# Altium schematic sheet SmartMeter.SchDoc(SmartMeter)
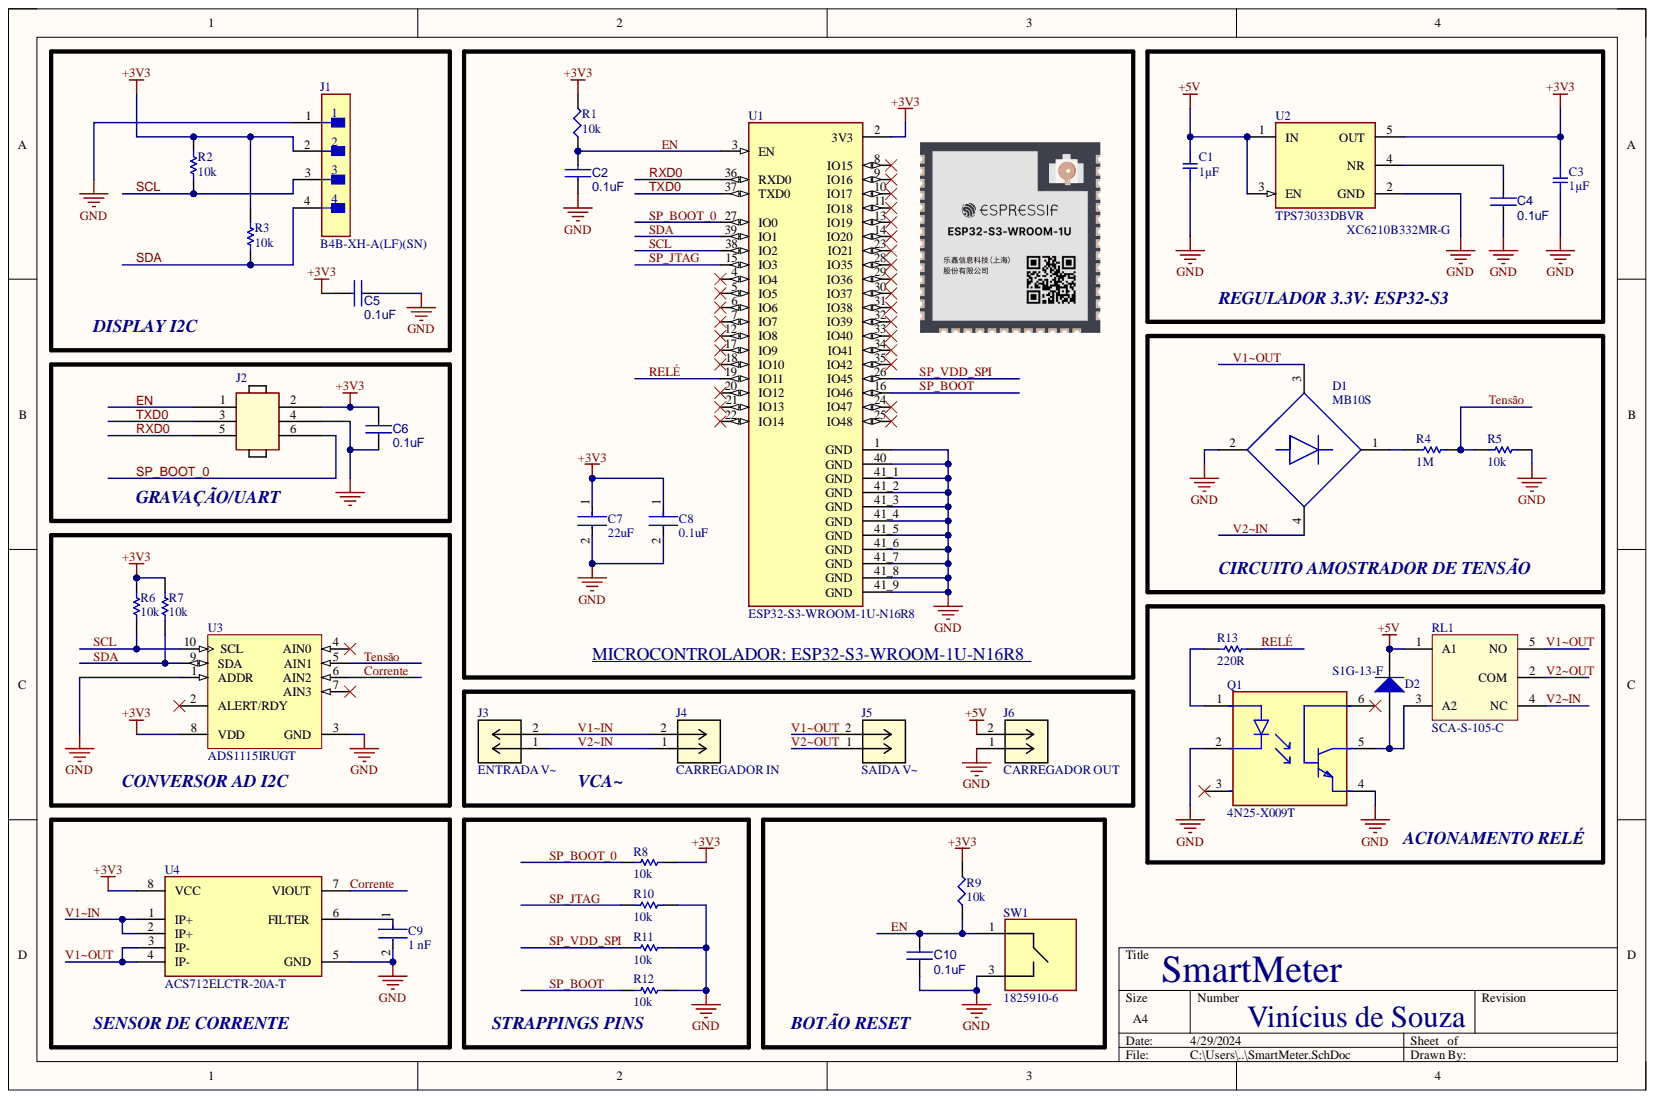
[diagram p0: SmartMeter.SchDoc]

## Parts
C1  1µF  [SMD-0805C]
C2  0.1uF  [C_0603]
C3  1µF  [SMD-0805C]
C4  0.1uF  [C_0603]
C5  0.1uF  [C_0603]
C6  0.1uF  [C_0603]
C7  22uF  [FP-C0603C104K5RAC3121-IPC_A]
C8  0.1uF  [FP-C0603C104K5RAC3121-IPC_A]
C9  1 nF  [FP-C0603C-CF-IPC_B]
C10  0.1uF  [C_0603]
D1  MB10S  [FP-751EP-IPC_A]
D2  S1G-13-F  [DIOD-SMA-2_V]  (no BOM)
J1  B4B-XH-A(LF)(SN)  [FP-B4B-XH-A_LF_SN-MFG]
J2  GRPB032VWVN-RC  [HDR6_M_P127]  (value not drawn)
J3  ENTRADA V~  [CONN_1715721_PXC]  (no BOM)
J4  CARREGADOR IN  [CONN_1715721_PXC]  (no BOM)
J5  SAÍDA V~  [CONN_1715721_PXC]  (no BOM)
J6  CARREGADOR OUT  [CONN_1715721_PXC]  (no BOM)
Q1  4N25-X009T  [FP-4N25-X009T-MFG]
R1  10k  [SMD-0603-RES]
R2  10k  [RESC1609X50X30LL8T20]
R3  10k  [RESC1609X50X30LL8T20]
R4  1M  [RESC1608X55X25NL10T15]
R5  10k  [RESC1609X50X30LL8T20]
R6  10k  [RESC1609X50X30LL8T20]
R7  10k  [RESC1609X50X30LL8T20]
R8  10k  [RESC1609X50X30LL8T20]
R9  10k  [SMD-0603-RES]
R10  10k  [RESC1609X50X30LL8T20]
R11  10k  [RESC1609X50X30LL8T20]
R12  10k  [RESC1609X50X30LL8T20]
R13  220R  [RESC1609X50X30LL8T20]
RL1  SCA-S-105-C  [RELAY_5V_MINI]
SW1  1825910-6  [18259106]
U1  ESP32-S3-WROOM-1U-N16R8  [XCVR_ESP32-S3-WROOM-1U-N16R8]
U2  TPS73033DBVR  [FP-DBV0005A-MFG]
U3  ADS1115IRUGT  [TI-RUG10_V]
U4  ACS712ELCTR-20A-T  [FP-LC-8-IPC_B]

## Nets
+3V3: C3.1 C5.2 C6.2 C7.1 C8.1 J1.2 J2.2 R1.2 R2.2 R3.2 R6.2 R7.2 R8.2 R9.2 U1.2(3V3) U2.5(OUT) U3.8(VDD) U4.8(VCC)
+5V: C1.1 D2.2 J6.2 RL1.1(A1) U2.1(IN) U2.3(EN)
GND: C1.2 C2.1 C3.2 C4.1 C5.1 C6.1 C7.2 C8.2 C9.2 C10.1 D1.2 J1.1 J2.4 J6.1 Q1.2 Q1.4 R5.2 R10.2 R11.2 R12.2 SW1.3 U1.1(GND) U1.40(GND) U1.41_1(GND) U1.41_2(GND) U1.41_3(GND) U1.41_4(GND) U1.41_5(GND) U1.41_6(GND) U1.41_7(GND) U1.41_8(GND) U1.41_9(GND) U2.2(GND) U3.1(ADDR) U3.3(GND) U4.5(GND)
Corrente: U3.6(AIN2) U4.7(VIOUT)
EN: C2.2 C10.2 J2.1 R1.1 R9.1 SW1.1 U1.3(EN)
RELÉ: R13.2 U1.19(IO11)
RXD0: J2.5 U1.36(RXD0)
SCL: J1.3 R2.1 R6.1 U1.38(IO2) U3.10(SCL)
SDA: J1.4 R3.1 R7.1 U1.39(IO1) U3.9(SDA)
SP_BOOT: R12.1 U1.16(IO46)
SP_BOOT_0: J2.6 R8.1 U1.27(IO0)
SP_JTAG: R10.1 U1.15(IO3)
SP_VDD_SPI: R11.1 U1.26(IO45)
Tensão: R4.2 R5.1 U3.5(AIN1)
TXD0: J2.3 U1.37(TXD0)
V1~IN: J3.2 J4.2 U4.1(IP+) U4.2(IP+)
V1~OUT: D1.3 J5.2 RL1.5(NO) U4.3(IP-) U4.4(IP-)
V2~IN: D1.4 J3.1 J4.1 RL1.4(NC)
V2~OUT: J5.1 RL1.2(COM)
NetC4_2: C4.2 U2.4(NR)
NetC9_1: C9.1 U4.6(FILTER)
NetD1_1: D1.1 R4.1
NetD2_1: D2.1 Q1.5 RL1.3(A2)
NetQ1_1: Q1.1 R13.1

Not connected: Q1 3(NC) 6; U1 4(IO4) 5(IO5) 6(IO6) 7(IO7) 8(IO15) 9(IO16) 10(IO17) 11(IO18) 12(IO8) 13(IO19) 14(IO20) 17(IO9) 18(IO10) 20(IO12) 21(IO13) 22(IO14) 23(IO21) 24(IO47) 25(IO48) 28(IO35) 29(IO36) 30(IO37) 31(IO38) 32(IO39) 33(IO40) 34(IO41) 35(IO42); U3 2(ALERT/RDY) 4(AIN0) 7(AIN3)

## Library data of the parts
C1 C3 = GCJ21BR71E105KA12L: CAP CER 1UF 25V X7R 0805; Manufacturer: Murata Electronics North America; @ qty: 0; Minimum Quantity: 1; Tolerance: ±10%; Unit Price (USD): 0.36; Applications: Automotive, Boardflex Sensitive; Component Kind: Standard; Datasheets: http://www.murata.com/~/media/webrenewal/support/library/catalog/products/capacitor/mlcc/c03e.ashx; Digi-Key Part Number: 490-5802-1-ND; Factory Stock: 0; Features: Soft Termination; Height - Seated (Max): -; Image: //media.digikey.com/Renders/Murata%20Renders/0805%20(2012%20Metric)%20.85mm.jpg; Lead Spacing: -; Lead Style: -; Library Name: capacitor_murata_0805.IntLib; Mounting Type: Surface Mount, MLCC; Operating Temperature: -55°C ~ 125°C; Package / Case: 0805 (2012 Metric); Packaging: Cut Tape (CT); Part Number: GCJ21BR71E105KA12L; Part Status: Active; Quantity Available: 15950; Ratings: AEC-Q200; Series: GCJ; Size / Dimension: 0.079" L x 0.049" W (2.00mm x 1.25mm); Temperature Coefficient: X7R; Thickness (Max): 0.057" (1.45mm); Value: 1µF; Voltage: 25V
C2 C4-C6 C10 = CAP NP: CAP CER 0.1UF 50V X7R 0603; Manufacturer: Murata Electronics; Manufacturer_Part_Number: C0603C104K5RAC3121; ManufacturerPN: GCJ188R71H104KA12D; PartNumber: FITC0000362; SchematicPart: discrete\CAPACITOR NON-POL; Capacitance: 100nF; LargeQuantityPrice: 0,02167; Obsolete: False; PCBFootPrint: smc0603; PCBFootPrint3D: 0603C; SmallQuantintyPrice: 0,24; Tolerance: ± 10 %; Voltage: 50.00 V; Availability: Active; Component Kind: Standard; Datasheet: https://www.murata.com/~/media/webrenewal/support/library/catalog/products/capacitor/mlcc/c03e.ashx?la=en-us; Distributor: Digikey; DistributorPN: 490-5798-6-ND; Package: 0603; PartType: Ceramic Capacitors; Temperature: -55° C ~ 125° C; Value: 0.1uF; Library Name: SMARTBIN.SCHLIB
  C2 C4 C5 C10: Case/Package: 0603
C7 C8 = CMP-03020-034644-1: CAP CER 0.1UF 50V X7R 0603; Case/Package: 0603; Component Kind: Standard; Library Name: Altium Content Vault; RoHS Compliant: Yes
C9 = CMP-03020-005970-1: C0603 1,000 pF X8R 30ppm/°C 1.00% 10 V  C0603C102F8HACAUTO; Manufacturer: KEMET; Automotive Grade: Grade 1; Material: Ceramic; Tolerance: 0.01; Voltage Rating: 10 V; Automotive: Yes; Capacitance: 1,000 pF; Category: Cap; Component Kind: Standard; ComponentLink2Description: Datasheet; ComponentLink2URL: https://api.kemet.com/component-edge/download/datasheet/C0603C100J5GACAUTO.pdf; Device Class L1: Passive Components; Device Class L2: Capacitors; Device Class L3: Ceramic Capacitors; Height: 0.87mm; Lead Free: Yes; Library Name: Altium Content Vault; Manufacturer Part Number: C0603C102F8HACAUTO; Octopart: https://octopart.com/c0603c102f8hacauto-kemet-88083731; Package: C0603C-CF; RoHS: TRUE; Temperature: -55°C to +125°C; Temperature Characteristic: X8R; Temperature Coefficient: 30ppm/°C; Temperature Range High: +125°C; Temperature Range Low: -55°C; Value: 1,000 pF
D1 = CMP-07163-000045-1: BRIDGE RECT 1P 1KV 500MA 4SOIC; Capacitance: 470pF; Element Configuration: Single; Forward Current: 800mA; Forward Voltage: 1V; Lead/Base Style: Formed Leads; Max Forward Surge Current (Ifsm): 35A; Max Power Dissipation: 1.4W; Number of Elements: 1; Peak Reverse Current: 5uA; Tolerance: 10%; Voltage Rating: 500V; Average Rectified Current: 500mA; Case Code (Imperial): 1206; Case Code (Metric): 3216; Case/Package: SOIC; Component Kind: Standard; Contact Plating: Tin; Height: 2.7mm; Height - Seated (Max): 0.354inch; Lead Free: Lead Free; Lead Pitch: 0.197inch; Length: 4.95mm; Library Name: MB10S.IntLib; Max Operating Temperature: 150°C; Max Repetitive Reverse Voltage (Vrrm): 1kV; Max Surge Current: 35A; Min Operating Temperature: -55°C; Mount: Surface Mount; Number of Pins: 4; Package Quantity: 500; Packaging: Tape and Reel; Peak Non-Repetitive Surge Current: 35A; Radiation Hardening: No; REACH SVHC: No SVHC; Reverse Voltage (DC): 1kV; Thickness: 0.039inch; Weight: 0.228g; Width: 4.2mm
D2 = CMP-2000-07012-1: Glass Passivated Rectifier, 1 A, 400 V, -65 to 150 degC, 2-Pin SMD, RoHS, Tape and Reel; Manufacturer: Diodes Inc; Average Rectified Current-Max: 1A; Forward Voltage: 1.1V; Peak Current-Max: 30A; Reverse Current-Max: 5µA; Reverse Voltage-Max [Vrrm]: 400V; Datasheet URL: http://www.diodes.com/_files/datasheets/ds16003.pdf; Datasheet Version: Rev. 24-2, 12/2014; Library Name: Altium Content Vault; Manufacturer URL: http://www.diodes.com/; Mounting Technology: SurfaceMount; Package Description: 2-Pin Surface Mounted Assembly, Body 4.3 x 2.605 mm, Height 2.4 mm; Package Reference: SMA-2
J1 = CMP-17439-000250-1: CONN HEADER VERT 4POS 2.5MM; Contact Resistance: 20mR; Current Rating: 3A; Gender: Male; Insulation Resistance: 1GR; Mated Stacking Heights: 9.8mm; Max Voltage Rating (AC): 250V; Number of Contacts: 4; Wire/Cable Gauge: 22AWG; Color: Natural; Component Kind: Standard; Connector Type: Header; Contact Finish - Mating: TIN; Contact Material: Brass; Contact Plating: Tin; Contact Shape: Square; Contact Type: Male Pin; Depth: 5.75mm; Fastening Type: Detent; Flammability Rating: UL94 V-0; Housing Color: White; Housing Material: Polyamide; Insulation Color: Natural; Insulation Material: Nylon; Lead Free: Lead Free; Lead Pitch: 2.5mm; Length: 12.4mm; Library Name: Altium Content Vault; Material: Polyamide; Max Current Rating: 3A; Max Operating Temperature: 85°C; Max Voltage Rating (DC): 250V; Min Operating Temperature: -25°C; Mount: Through Hole; Number of Positions: 4; Number of Rows: 1; Orientation: Straight; Package Quantity: 500; Packaging: Bulk; Pitch: 2.5mm; REACH SVHC: No SVHC; Series: XH; Termination: Solder; Voltage Rating: 250V; Voltage Rating (AC): 250V
J2 = HEADER 3X2 1.27mm: CONN HEADER VERT 6POS 1.27MM; Manufacturer: Sullins Connector Solutions; PartNumber: FITJ0000168; SchematicPart: CONNECTOR\HEADER 3X2; LargeQuantityPrice: 0,2158; NumberOfPositions: 6; Obsolete: False; SmallQuantintyPrice: 0,48; Voltage: 0.00 V; Availability: Active; Component Kind: Standard; Datasheet: https://media.digikey.com/pdf/Data%20Sheets/Sullins%20PDFs/GRPB_%20_2VWVN-RC%2010954-C.pdf; Distributor: Digikey; DistributorPN: S9015E-03-ND; ManufacturerPN: GRPB032VWVN-RC; PartType: Header; Temperature: -40° C ~ 105° C; Value: GRPB032VWVN-RC; Library Name: Pollux.IntLib
J3-J6 = 1715721: TERM BLK 2P SIDE ENT 5.08MM PCB; Manufacturer: Phoenix Contact; Approvals: UL,CSA,cULus,EAC; Connector Type: Screw,Terminal Block,PCB; Current: 15A; Current Rating: 17.5A; Max Current Rating: 22A; Max Supply Voltage: 250V; Number of Circuits: 2; Number of Levels: 1; Stripping Length: 7mm; Test Voltage: 4kV; Voltage: 300V; Voltage Rating: 400V; Wire Gauge (Max): 26AWG; Wire Gauge (Min): 14AWG; Category: Terminal Blocks; Color: Green; ComponentLink1Description: https://datasheet.ciiva.com/16046/1715721-16046034.pdf?src-supplier=Onlinecomponents.com; ComponentLink1URL: https://datasheet.ciiva.com/16046/1715721-16046034.pdf?src-supplier=Onlinecomponents.com; ComponentLink2Description: https://datasheet.ciiva.com/15169/getdatasheetpartid-212174-15169929.pdf?src-supplier=Verical; ComponentLink2URL: https://datasheet.ciiva.com/15169/getdatasheetpartid-212174-15169929.pdf?src-supplier=Verical; ComponentLink3Description: https://datasheet.ciiva.com/832/1715721-832095.pdf?src-supplier=Digi-Key; ComponentLink3URL: https://datasheet.ciiva.com/832/1715721-832095.pdf?src-supplier=Digi-Key; ComponentLink4Description: https://datasheet.ciiva.com/1120/1697161-1120393.pdf?src-supplier=Farnell; ComponentLink4URL: https://datasheet.ciiva.com/1120/1697161-1120393.pdf?src-supplier=Farnell; Contact Material: Tin; Depth: 9.8mm; Flammability Rating: UL94 V-0; Height: 17.3mm; Housing Color: Green; Housing Material: Polyamide; Insulation Material: Nylon; Lead Free: Lead Free; Length: 10.16mm; ManufacturerPN: 1715721; Max Operating Temperature: 100°C; Min Operating Temperature: -20°C; Mount: Through Hole; Number of Contacts: 2; Number of Pins: 2; Number of Poles: 2; Number of Positions: 2; Number of Positions Per Level: 2; Number of Rows: 1; Orientation: Straight; Package Quantity: 50; Packaging: Bulk; Pitch: 5.08mm; Pricing 1: 5=1,16200, 75=1,06000, 250=0,96800, 500=0,89200, 1000=0,82200 (GBP); Radiation Hardening: No; Reach SVHC Compliant: Yes; RoHS: Yes; RoHS Compliant: Yes; Series: MKDS; Stock 1: 2745; Supplier 1: Rs; Supplier Part Number 1: 1930564; Termination: Screw; Voltage Rating (AC): 250V; Weight: 0.103705oz; Width: 13.8mm; Wire/Cable Gauge: 14AWG; Library Name: POC_RELES.SchLib
Q1 = CMP-00030-12292229-1: 4N25-X009T Optocoupler DC-IN 1-CH Transistor With Base DC-OUT 6-Pin PDIP SMD T/R; Collector Emitter Saturation Voltage: 500mV; Collector Emitter Voltage (VCEO): 70V; Current Transfer Ratio: 50%; Forward Current: 60mA; Forward Voltage: 1.36V; Max Collector Current: 100mA; Max Power Dissipation: 150mW; Number of Channels: 1; Output Current per Channel: 50mA; Reverse Breakdown Voltage: 6V; Case/Package: SMD/SMT; Component Kind: Standard; Contact Plating: Tin; Lead Free: Lead Free; Library Name: 4N25.IntLib; Max Input Current: 60mA; Max Operating Temperature: 100°C; Max Output Voltage: 70V; Min Operating Temperature: -55°C; Mount: Surface Mount; NexarPartId: 12292229; Number of Elements: 1; Number of Pins: 6; Packaging: Tape & Reel (TR); Power Dissipation: 150mW; Radiation Hardening: No; RoHS: Compliant
R1 R9 = ERJ-3EKF1002V: RES SMD 10K OHM 1% 1/10W 0603; Manufacturer: Panasonic Electronic Components; @ qty: 1500; Factory Stock: 0; Minimum Quantity: 1; Power (Watts): 0.1W, 1/10W; Temperature Coefficient: ±100ppm/°C; Unit Price (USD): 0.00379; Component Kind: Standard; Composition: Thick Film; Datasheets: http://industrial.panasonic.com/www-cgi/jvcr13pz.cgi?E+PZ+3+AOA0002+ERJ3EKF1002V+7+WW; Digi-Key Part Number: P10.0KHCT-ND; Failure Rate: -; Features: Automotive AEC-Q200; Height - Seated (Max): 0.022" (0.55mm); Image: //media.digikey.com/Photos/Panasonic%20Photos/MFG_ERJ-P6W%20Series.jpg; Library Name: Panasonic_ERJ_0603.IntLib; Number of Terminations: 2; Operating Temperature: -55°C ~ 155°C; Package / Case: 0603 (1608 Metric); Packaging: Cut Tape (CT); Part Number: ERJ-3EKF1002V; Part Status: Active; Quantity Available: 6703141; Series: ERJ; Size / Dimension: 0.063" L x 0.031" W (1.60mm x 0.80mm); Supplier Device Package: 0603; Tolerance: ±1%; Value: 10k
R2 R3 R5-R8 R10-R13 = CMP-1666-00005-4: Chip Resistor, 10 KOhm, +/- 1%, 100 mW, -55 to 155 degC, 0603 (1608 Metric), RoHS, Tape and Reel; Manufacturer: Vishay Semiconductor; Voltage Rating: 75 V; Component Kind: Standard; ComponentLink1Description: Manufacturer URL; ComponentLink1URL: http://www.vishay.com/; ComponentLink2Description: Datasheet; ComponentLink2URL: https://datasheet.ciiva.com/11809/0900766b80d98afc-11809037.pdf; DatasheetVersion: 06/2012; Height: 0.45 mm; Length: 1.55 mm; Library Name: Altium Content Vault; Max Operating Temperature: 155 degC; Min Operating Temperature: -55 degC; Mounting Technology: Surface Mount; Package Quantity: 5000; PackageDescription: 2-Pin Surface Mount Device, Body 1.575 x 0.85 mm; PackageReference: 0603; Packaging: Tape and Reel; REACH SVHC: Unknown; RoHSCompliant: true
R4 = CMP-1659-00056-1: Chip Resistor, 1 MOhm, +/- 1%, 100 mW, -55 to 155 degC, 0603 (1608 Metric), RoHS, Tape and Reel; Capacitance: 1 mF; Case\Package: Radial; Tolerance: 20%; Voltage Rating: 25 V; Component Kind: Standard; ComponentLink1Description: Manufacturer URL; ComponentLink1URL: http://www.yageo.com/; ComponentLink2Description: Datasheet; ComponentLink2URL: http://www.yageo.com/documents/recent/PYu-RC_Group_51_RoHS_L_04.pdf; DatasheetVersion: Rev. 4, 02/2016; Diameter: 10 mm; Height: 20 mm; Lead Pitch: 5 mm; Length: 20 mm; Library Name: 06031M.IntLib; Max Operating Temperature: 85 degC; Min Operating Temperature: -40 degC; Mount: Through Hole; Package Quantity: 200; PackageDescription: 2-Pin Surface Mount Device, Body 1.6 x 0.8 mm; PackageReference: 0603; Packaging: Bulk; Radiation Hardening: No; REACH SVHC: No SVHC; RoHSCompliant: true; Voltage Rating (DC): 25 V; Width: 0 inch
RL1 = SCA-S-105-C: RELAY 5VDC UP TO 15A; Component Kind: Standard; Library Name: CASTOR_ED01V1.SchLib
SW1 = 1825910-6: Switch; Manufacturer_Name: TE Connectivity; Arrow Part Number: 1825910-6; Arrow Price/Stock: https://www.arrow.com/en/products/1825910-6/te-connectivity?region=europe; Component Kind: Standard; Datasheet Link: https://www.te.com/commerce/DocumentDelivery/DDEController?Action=srchrtrv&DocNm=1825910&DocType=Customer+Drawing&DocLang=English&DocFormat=pdf&PartCntxt=1825910-6; Height: 5.3mm; Library Name: SamacSys.SchLib; Manufacturer_Part_Number: 1825910-6; Mouser Part Number: N/A; Mouser Price/Stock: https://www.mouser.co.uk/ProductDetail/TE-Connectivity/1825910-6?qs=A6cQTCBcXncisYDS1KbpGA%3D%3D
U1 = ESP32-S3-WROOM-1U-N16R8: Bluetooth, WiFi 802.11b/g/n, Bluetooth v5.0 Transceiver Module 2.4GHz Antenna Not Included, U.FL Surface Mount; MF: Espressif Systems; Availability: Unavailable; Check_prices: https://www.snapeda.com/parts/ESP32-S3-WROOM-1U-N16R8/Espressif+Systems/view-part/?ref=eda; Component Kind: Standard; Library Name: ESP32-S3-WROOM-1U-N16R8.IntLib; MP: ESP32-S3-WROOM-1U-N16R8; Package: None; Price: None; SnapEDA_Link: https://www.snapeda.com/parts/ESP32-S3-WROOM-1U-N16R8/Espressif+Systems/view-part/?ref=snap
U2 = CMP-04917-000026-1: IC REG LINEAR 3.3V 200MA SOT23-5; Manufacturer: Texas Instruments; Accuracy Percentage: 2%; Dropout Voltage: 120mV; Max Junction Temp: +125°C; Max Supply Voltage: 5.5V; Min Supply Voltage: 2.7V; Nominal Supply Current: 170uA; Number Of Outputs: 1; Output Type: Fixed; Standoff Height: 0mm; Automotive: No; Category: IC; Component Kind: Standard; ComponentLink2Description: Datasheet; ComponentLink2URL: http://www.ti.com/lit/ds/symlink/tps730.pdf; Device Class L1: Integrated Circuits (ICs); Device Class L2: Power Management ICs; Device Class L3: Voltage Regulators - Linear; Digikey Description: IC REG LINEAR 3.3V 200MA SOT23-5; Height: 1.45mm; Lead Free: Yes; Library Name: Altium Content Vault; Manufacturer Part Number: TPS73033DBVR; Mouser Description: IC REG LINEAR 3.3V 200MA SOT23-5; Octopart: https://octopart.com/tps73033dbvr-texas+instruments-525554; Output Current: 200mA; Output Voltage: 3.3V; Package: DBV0005A; RoHS: TRUE; Temperature: -40°C to +125°C; Temperature Range High: +125°C; Temperature Range Low: -40°C
U3 = CMP-0003-00971-1: 16-Bit ADC with Integrated Multiplexer, PGA, Comparator, Oscillator, and Reference, -40 to 125 degC, 10-pin HandDrawn (RUG10), Green (RoHS & no Sb/Br); Manufacturer: Texas Instruments; # Input Channels: 4; Analog Voltage AV/DD(Max)(V): 5.5; Analog Voltage AV/DD(Min)(V): 2; Architecture: Delta-Sigma; INL(Max)(+/-LSB): 1; Interface: Serial I2C; Resolution(Bits): 16; Sample Rate (max)(SPS): 860; SubFamily: Precision ADC (<=10MSPS); Code_JEDEC: MO-288; Component Kind: Standard; ComponentLink1Description: Manufacturer URL; ComponentLink1URL: http://www.ti.com/; ComponentLink2Description: Datasheet; ComponentLink2URL: http://www.ti.com/general/docs/lit/getliterature.tsp?genericPartNumber=ADS1115&fileType=pdf; ComponentLink3Description: Package Specification; ComponentLink3URL: http://www.ti.com/litv/pdf/mpqf216a; DatasheetVersion: SBAS444B; Digital Supply(Max)(V): 5.5; Digital Supply(Min)(V): 2; Eco-Plan: Green (RoHS & no Sb/Br); Library Name: ADS1115.SchLib; Mounting Technology: Surface Mount; PackageDescription: 10-Pin Plastic Quad Flatpack, Body 1.5 x 2 mm, Pitch 0.5 mm; PackageReference: RUG10; PackageVersion: 4208528-3/B, 04/2008; PartNumber: ADS1115IRUGT
U4 = CMP-0826-00001-2: SENSOR CURRENT HALL 20A AC/DC; Accuracy: 1.5%; Bandwidth: 80kHz; Max Supply Current: 13mA; Max Supply Voltage: 5.5V; Min Supply Voltage: 4.5V; Number of Channels: 1; Operating Supply Voltage: 5V; Output Current: 10mA; Polarity: Bidirectional; Response Time: 5us; Sensitivity: 100mV/A; Case/Package: SOIC; Component Kind: Standard; Current Sensing: 20A; Frequency: 80kHz; Height: 1.5mm; Lead Free: Lead Free; Length: 4.9mm; Library Name: ACS71220A.IntLib; Linearity: 1.5%; Max Operating Temperature: 85°C; Min Operating Temperature: -40°C; Number of Pins: 8; Package Quantity: 1; Packaging: Tape and Reel; Quiescent Current: 10mA; Radiation Hardening: No; REACH SVHC: No SVHC; RoHS Compliant: Yes; Series: -; Termination: SMD/SMT; Width: 3.9mm
